# Altium schematic sheet [12]-Configuration EEPROM.SchDoc
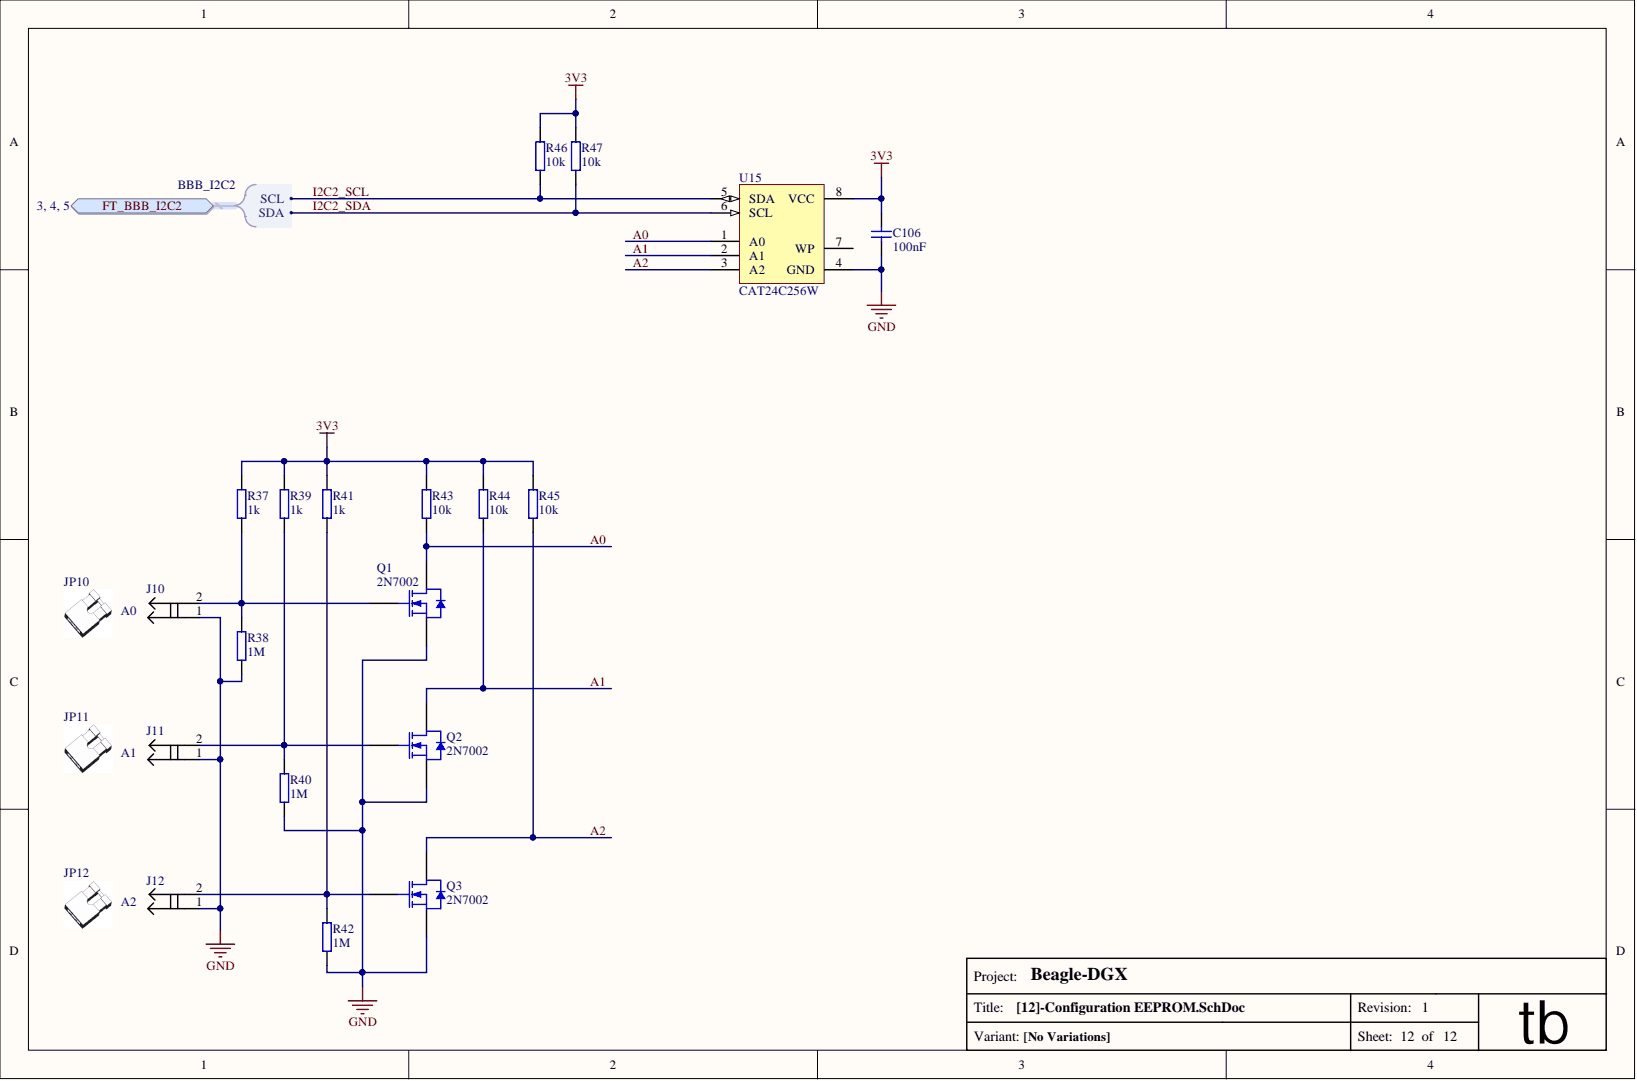
[diagram p0: [12]-Configuration EEPROM.SchDoc]

## Parts
C106  value 100nF  [C0603]  (hidden comment: CAP)
J10  A0  [Jumper_2pin white_open]
J11  A1  [Jumper_2pin white_open]
J12  A2  [Jumper_2pin white_open]
JP10  Addr_sel  (value not drawn)  (mechanical)
JP11  Addr_sel  (value not drawn)  (mechanical)
JP12  Addr_sel  (value not drawn)  (mechanical)
Q1  2N7002  [SOT23-3]
Q2  2N7002  [SOT23-3]
Q3  2N7002  [SOT23-3]
R37  value 1k  [0603_RESISTOR]  (hidden comment: Resistor)
R38  value 1M  [0603_RESISTOR]  (hidden comment: Resistor)
R39  value 1k  [0603_RESISTOR]  (hidden comment: Resistor)
R40  value 1M  [0603_RESISTOR]  (hidden comment: Resistor)
R41  value 1k  [0603_RESISTOR]  (hidden comment: Resistor)
R42  value 1M  [0603_RESISTOR]  (hidden comment: Resistor)
R43  value 10k  [0603_RESISTOR]  (hidden comment: Resistor)
R44  value 10k  [0603_RESISTOR]  (hidden comment: Resistor)
R45  value 10k  [0603_RESISTOR]  (hidden comment: Resistor)
R46  value 10k  [0603_RESISTOR]  (hidden comment: Resistor)
R47  value 10k  [0603_RESISTOR]  (hidden comment: Resistor)
U15  CAT24C256W  [SOIC-8 150 Mil]

## Ports
FT_BBB_I2C2, FT_BBB_I2C2.SCL, FT_BBB_I2C2.SDA

## Nets
3V3: C106.1 R37.1 R39.1 R41.1 R43.2 R44.2 R45.2 R46.2 R47.2 U15.8(VCC)
GND: C106.2 J10.1 J11.1 J12.1 Q1.2 Q2.2 Q3.2 R38.1 R40.1 R42.1 U15.4(GND)
A0: Q1.3 R43.1 U15.1(A0)
A1: Q2.3 R44.1 U15.2(A1)
A2: Q3.3 R45.1 U15.3(A2)
I2C2_SCL: R46.1 U15.5(SDA) ; port FT_BBB_I2C2
I2C2_SDA: R47.1 U15.6(SCL) ; port FT_BBB_I2C2
NetJ10_2: J10.2 Q1.1 R37.2 R38.2
NetJ11_2: J11.2 Q2.1 R39.2 R40.2
NetJ12_2: J12.2 Q3.1 R41.2 R42.2

Not connected: U15 7(WP)

## Library data of the parts
C106 = CAP: Type of Component: Ceramic Capacitor; Component Kind: Standard; Package / Case: 0603; Library Name: RPI-VITA.IntLib
J10-J12 = jumper_2pin_open: Jumper 2pin Open; Type of Component: Header for jumper 2 pin; Value: Header 1x2; Component Kind: Standard; Package / Case: 2.54mm; Supplier 1: aliexpress.com; Supplier 1 Link: aliexpress.com/item/1Set-20PCS-40Pin-2-54mm-Single-Row-Straight-Male-Pin-Header-Connector-Strip-For-PBC-Ardunio/32329088739.html?spm=2114.03010208.3.2.0ijeiM&ws_ab_test=searchweb201556_1,searchweb201644_1_505_506_503_504_502_10001_10002_10017_10010_10005_10011_10006_10003_10004_10009_10007_10008,searchweb201560_5,searchweb1451318400_-1,searchweb1451318411_6452&btsid=d8fe2734-cbe4-429c-a307-e5400297e31b; Supplier 2: http://imrad.com.ua; Supplier 2 Link: http://imrad.com.ua/ru/pls-40-product-122266; Supplier 2 Part: 202826; Supplier 3: http://voron.ua; Supplier 3 Link: http://voron.ua/catalog/000631; Supplier 3 Part: 000631; Library Name: RPI-VITA.IntLib
JP10-JP12 = jumper white: Library Name: RPI-VITA.IntLib
R37 R39 R41 = Resistor: Manufacturer: UniOhm; Manufacturer Part: 0603 1k; Component Kind: Standard; Package / Case: 0603; Supplier: aliexpress.com; Supplier Link: aliexpress.com/store/product/0603-SMD-Resistor-1-1K-ohm-5000pcs-lot/506373_32306337400.html?storeId=506373&spm=2114.12010208.100007.289.z8ByJf; Supplier Part: 0603 1k; Type of Component: Resistor; Library Name: RPI-VITA.IntLib
R38 R40 R42 = Resistor: Manufacturer: UniOhm; Manufacturer Part: 0603 1M; Component Kind: Standard; Package / Case: 0603; Supplier: aliexpress.com; Supplier Link: aliexpress.com/store/product/0603-SMD-Resistor-1-3-01K-ohm-5000pcs-lot/506373_32308172298.html?detailNewVersion=&categoryId=400503&spm=2114.12010208.1000023.342.unm836; Supplier Part: 0603 1M; Type of Component: Resistor; Library Name: RPI-VITA.IntLib
U15 = 24LC128: Type of Component: EEPROM; Component Kind: Standard; Manufacturer Part: CAT24C256W; Package / Case: SOIC-8 150 Mil; Value: CAT24C256W; Library Name: RPI-VITA.IntLib
Q1-Q3 = 2N7002: Component Kind: Standard; Library Name: RPI-VITA.IntLib
R43-R47 = Resistor: Component Kind: Standard; Package / Case: 0603; Supplier 1: aliexpress.com; Supplier 1 Link: aliexpress.com/store/product/0603-SMD-Resistor-5-10K-ohm-5000pcs-lot/506373_32307351301.html?storeId=506373&spm=2114.12010208.100007.103.XAQnpm; Supplier 1 Part: 0603 10k; Supplier 2: http://imrad.com.ua/; Supplier 2 Link: http://imrad.com.ua/ru/rc0603jr-0710kl; Supplier 2 Part: 183440; Supplier 3: http://www.kosmodrom.com.ua; Supplier 3 Link: http://www.kosmodrom.com.ua/prodlist.php?page=0&name=%280603%29+10+kom&okbutton=%CF%EE%E8%F1%EA+%EF%EE+%F1%EA%EB%E0%E4%F3; Supplier 3 Part: (0603) 10 kom; Type of Component: Resistor; Library Name: RPI-VITA.IntLib
